# Altium schematic sheet WRTnode.SchDoc
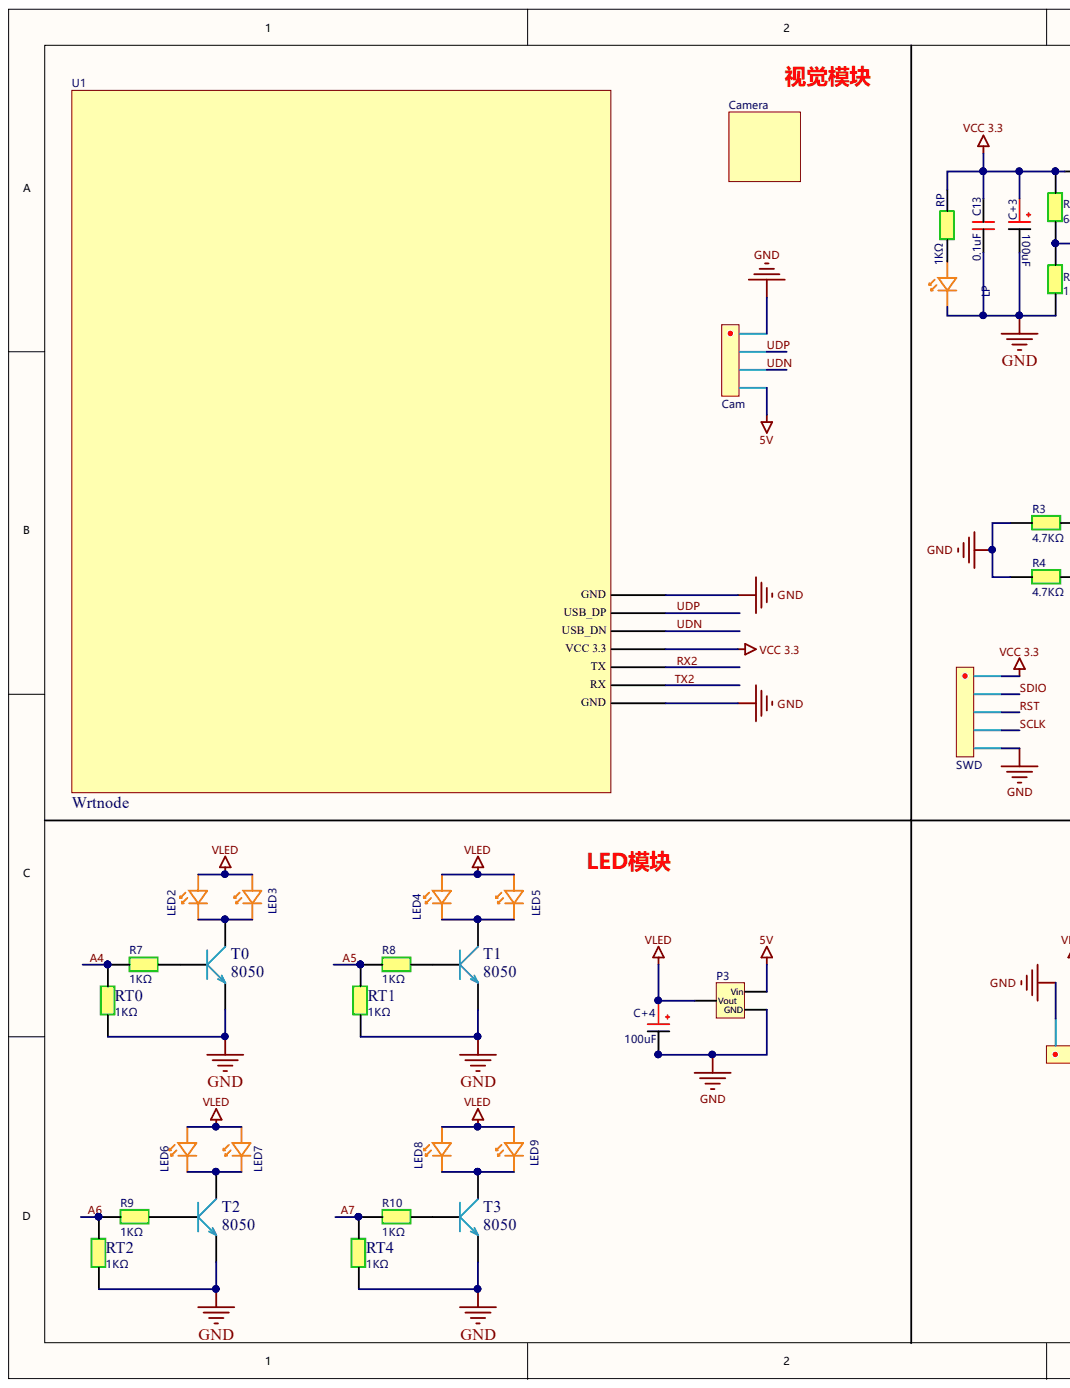
[diagram p0: WRTnode.SchDoc, left]
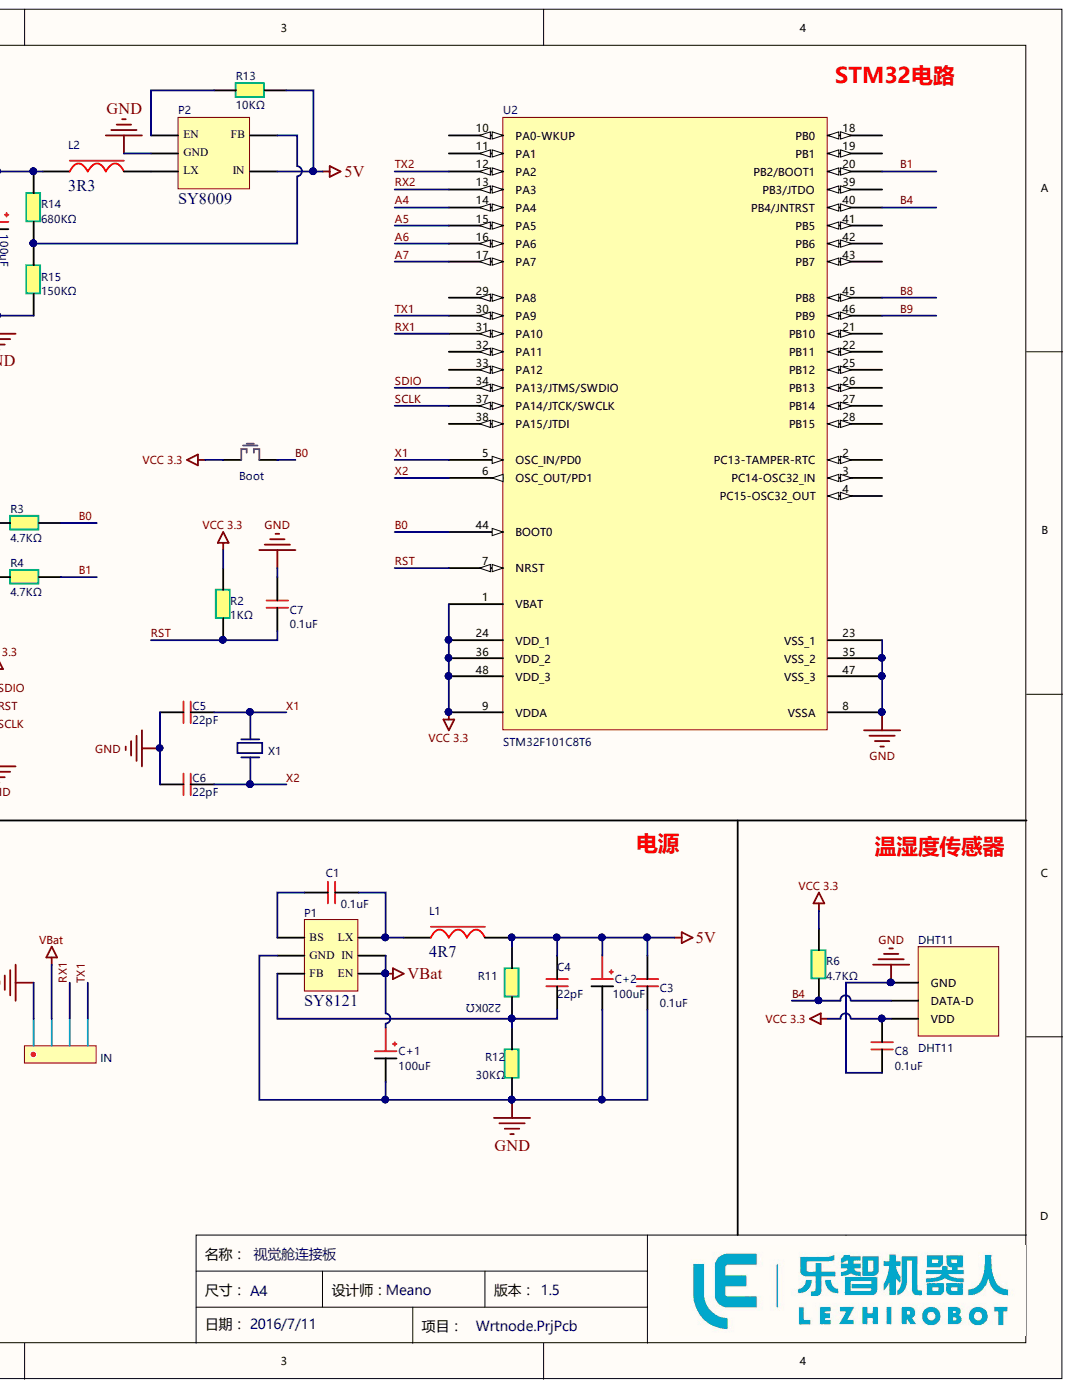
[diagram p1: WRTnode.SchDoc, right]

## Parts
Boot  Key  [KEY]  (value not drawn)
C1  value 0.1uF  [Cap - 0805]  (hidden comment: Cap)
C3  value 0.1uF  [Cap - 0805]  (hidden comment: Cap)
C4  value 22pF  [Cap - 0805]  (hidden comment: Cap)
C5  value 22pF  [Cap - 0805]  (hidden comment: Cap)
C6  value 22pF  [Cap - 0805]  (hidden comment: Cap)
C7  value 0.1uF  [Cap - 0805]  (hidden comment: Cap)
C8  value 0.1uF  [Cap - 0805]  (hidden comment: Cap)
C13  value 0.1uF  [Cap - 0805]  (hidden comment: Cap)
C+1  value 100uF  [SMD-EC-F6.3]  (hidden comment: CapPlus)
C+2  value 100uF  [SMD-EC-F6.3]  (hidden comment: CapPlus)
C+3  value 100uF  [SMD-EC-F6.3]  (hidden comment: CapPlus)
C+4  value 100uF  [SMD-EC-F6.3]  (hidden comment: CapPlus)
Cam  PH2.0-4P  [PH2.0-4P]  (value not drawn)
Camera  (no value)  [USB camera]
DHT11  DHT11  [DHT11]
IN  PH2.0-4P  [PH2.0-4P]  (value not drawn)
L1  4R7  [7*7*4]
L2  3R3  [7*7*4]
LED2  LED Light  [LED 3528]  (value not drawn)
LED3  LED Light  [LED 3528]  (value not drawn)
LED4  LED Light  [LED 3528]  (value not drawn)
LED5  LED Light  [LED 3528]  (value not drawn)
LED6  LED Light  [LED 3528]  (value not drawn)
LED7  LED Light  [LED 3528]  (value not drawn)
LED8  LED Light  [LED 3528]  (value not drawn)
LED9  LED Light  [LED 3528]  (value not drawn)
LP  LED Light  [LED - 0805]  (value not drawn)
P1  SY8121  [SY8121]
P2  SY8009  [SY8009]
P3  PowerIC  [ASM1117]  (value not drawn)
R2  value 1KΩ  [Res - 0805]  (hidden comment: Res)
R3  value 4.7KΩ  [Res - 0805]  (hidden comment: Res)
R4  value 4.7KΩ  [Res - 0805]  (hidden comment: Res)
R6  value 4.7KΩ  [Res - 0805]  (hidden comment: Res)
R7  value 1KΩ  [Res - 0805]  (hidden comment: Res)
R8  value 1KΩ  [Res - 0805]  (hidden comment: Res)
R9  value 1KΩ  [Res - 0805]  (hidden comment: Res)
R10  value 1KΩ  [Res - 0805]  (hidden comment: Res)
R11  value 220KΩ  [Res - 0805]  (hidden comment: Res)
R12  value 30KΩ  [Res - 0805]  (hidden comment: Res)
R13  value 10KΩ  [Res - 0805]  (hidden comment: Res)
R14  value 680KΩ  [Res - 0805]  (hidden comment: Res)
R15  value 150KΩ  [Res - 0805]  (hidden comment: Res)
RP  value 1KΩ  [Res - 0805]  (hidden comment: Res)
RT0  value 1KΩ  [Res - 0805]  (hidden comment: Res)
RT1  value 1KΩ  [Res - 0805]  (hidden comment: Res)
RT2  value 1KΩ  [Res - 0805]  (hidden comment: Res)
RT4  value 1KΩ  [Res - 0805]  (hidden comment: Res)
SWD  PH2.0-5P  [PH2.0-5P]  (value not drawn)
T0  8050  [9014 SOT23]
T1  8050  [9014 SOT23]
T2  8050  [9014 SOT23]
T3  8050  [9014 SOT23]
U1  Wrtnode  [Wrtnode]
U2  STM32F101C8T6  [LQFP48_L]
X1  XTAL  [XTAL SMD]  (value not drawn)

## Nets
5V: C3.2 C4.2 C+2.1 Cam.4 L1.2 P2.4(IN) P3.3 R11.1 R13.2
GND: C3.1 C5.2 C6.2 C7.2 C8.1 C13.2 C+1.2 C+2.2 C+3.2 C+4.2 Cam.1 DHT11.4 IN.1 LP.2 P1.2(GND) P2.2(GND) P3.1 R3.1 R4.1 R12.1 R15.1 RT0.1 RT1.1 RT2.1 RT4.2 SWD.5 T0.2 T1.2 T2.2 T3.2 U1.24(GND) U1.30(GND) U2.8(VSSA) U2.23(VSS_1) U2.35(VSS_2) U2.47(VSS_3)
VBAT: C+1.1 IN.2 P1.4(EN) P1.5(IN)
VCC 3.3: Boot.2 C8.2 C13.1 C+3.1 DHT11.1 L2.1 R2.2 R6.2 R14.1 RP.2 SWD.1 U1.27(VCC_3.3) U2.1(VBAT) U2.9(VDDA) U2.24(VDD_1) U2.36(VDD_2) U2.48(VDD_3)
VLED: C+4.1 LED2.1 LED3.1 LED4.1 LED5.1 LED6.1 LED7.1 LED8.1 LED9.1 P3.2
A4: R7.1 RT0.2 U2.14(PA4)
A5: R8.1 RT1.2 U2.15(PA5)
A6: R9.1 RT2.2 U2.16(PA6)
A7: R10.1 RT4.1 U2.17(PA7)
B0: Boot.1 R3.2 U2.44(BOOT0)
B1: R4.2 U2.20(PB2/BOOT1)
B4: DHT11.2 R6.1 U2.40(PB4/JNTRST)
B8: U2.45(PB8)
B9: U2.46(PB9)
RST: C7.1 R2.1 SWD.3 U2.7(NRST)
RX1: IN.3 U2.31(PA10)
RX2: U1.75(TX) U2.13(PA3)
SCLK: SWD.4 U2.37(PA14/JTCK/SWCLK)
SDIO: SWD.2 U2.34(PA13/JTMS/SWDIO)
TX1: IN.4 U2.30(PA9)
TX2: U1.62(RX) U2.12(PA2)
UDN: Cam.3 U1.26(USB_DN)
UDP: Cam.2 U1.25(USB_DP)
X1: C5.1 U2.5(OSC_IN/PD0) X1.2
X2: C6.1 U2.6(OSC_OUT/PD1) X1.1
NetC1_1: C1.1 P1.1(BS)
NetC1_2: C1.2 L1.1 P1.6(LX)
NetC4_1: C4.1 P1.3(FB) R11.2 R12.2
NetL2_2: L2.2 P2.3(LX)
NetLED2_2: LED2.2 LED3.2 T0.3
NetLED4_2: LED4.2 LED5.2 T1.3
NetLED6_2: LED6.2 LED7.2 T2.3
NetLED8_2: LED8.2 LED9.2 T3.3
NetLP_1: LP.1 RP.1
NetP2_1: P2.1(EN) R13.1
NetP2_5: P2.5(FB) R14.2 R15.2
NetR7_2: R7.2 T0.1
NetR8_2: R8.2 T1.1
NetR9_2: R9.2 T2.1
NetR10_2: R10.2 T3.1

Not connected: U2 2(PC13-TAMPER-RTC) 3(PC14-OSC32_IN) 4(PC15-OSC32_OUT) 10(PA0-WKUP) 11(PA1) 18(PB0) 19(PB1) 21(PB10) 22(PB11) 25(PB12) 26(PB13) 27(PB14) 28(PB15) 29(PA8) 32(PA11) 33(PA12) 38(PA15/JTDI) 39(PB3/JTDO) 41(PB5) 42(PB6) 43(PB7)

## Library data of the parts
Boot = Key: Key2; Component Kind: Standard; Library Name: SmartTuna-ESchLib.SchLib
C1 C3-C8 C13 = Cap: Cap Normal; Component Kind: Standard; Library Name: SmartTuna-ESchLib.SchLib
C+1-C+4 = Cap+: Component Kind: Standard; Description: Cap+; Library Name: SmartTuna-ESchLib.SchLib
Camera = USB camera: Component Kind: Standard; Library Name: SmartTuna-ESchLib.SchLib
L1 = LH: Value: 4.7uH; Component Kind: Standard; Library Name: SmartTuna-ESchLib.SchLib
L2 = LH: Value: 3.3uH; Component Kind: Standard; Library Name: SmartTuna-ESchLib.SchLib
LED2-LED9 LP = LED: Component Kind: Standard; Library Name: SmartTuna-ESchLib.SchLib
P3 = ASM1117 - 3.3: PowerControl; Component Kind: Standard; Library Name: SmartTuna-ESchLib.SchLib
T0-T3 = NPN8050: 9014; Component Kind: Standard; Library Name: SmartTuna-ESchLib.SchLib
U2 = STM32F101C8T6: STM32 ARM-based 32-bit MCU with 64 Kbytes Flash, 48-pin LQFP, Industrial Temperature; Component Kind: Standard; ComponentLink1Description: Manufacturer Link; ComponentLink1URL: www.st.com/stm32; ComponentLink2Description: Datasheet; ComponentLink2URL: http://www.st.com/stonline/products/literature/ds/13586.pdf; DatasheetVersion: rev6, Mar-2008; PackageDescription: 48-Pin Low-Profile Quad Flat Pack, 7 x 7 mm Body, 0.5 mm Pitch (LQFP48); PackageReference: LQFP48; PackageVersion: Mar-2008; Published: 30-May-2008; Library Name: SmartTuna-ESchLib.SchLib; Publisher: Altium Limited
Cam IN = PH2.0-4P: Component Kind: Standard; Description: PH2.0-4P; Library Name: SmartTuna-ESchLib.SchLib
DHT11 = DHT11: Component Kind: Standard; Library Name: SmartTuna-ESchLib.SchLib
P1 = SY8121: Component Kind: Standard; Library Name: SmartTuna-ESchLib.SchLib
P2 = SY8009: Component Kind: Standard; Library Name: SmartTuna-ESchLib.SchLib
R2-R4 R6-R15 RP RT0-RT2 RT4 = Res: Component Kind: Standard; Description: Res; Library Name: SmartTuna-ESchLib.SchLib
SWD = PH2.0-5P: Component Kind: Standard; Description: PH2.0-5P; Library Name: SmartTuna-ESchLib.SchLib
U1 = Wrtnode: Component Kind: Standard; Description: Wrtnode; Library Name: SmartTuna-ESchLib.SchLib
X1 = XTAL: Component Kind: Standard; Description: XTAL; Library Name: SmartTuna-ESchLib.SchLib
